# Altium schematic sheet Codec.SchDoc(Codec)
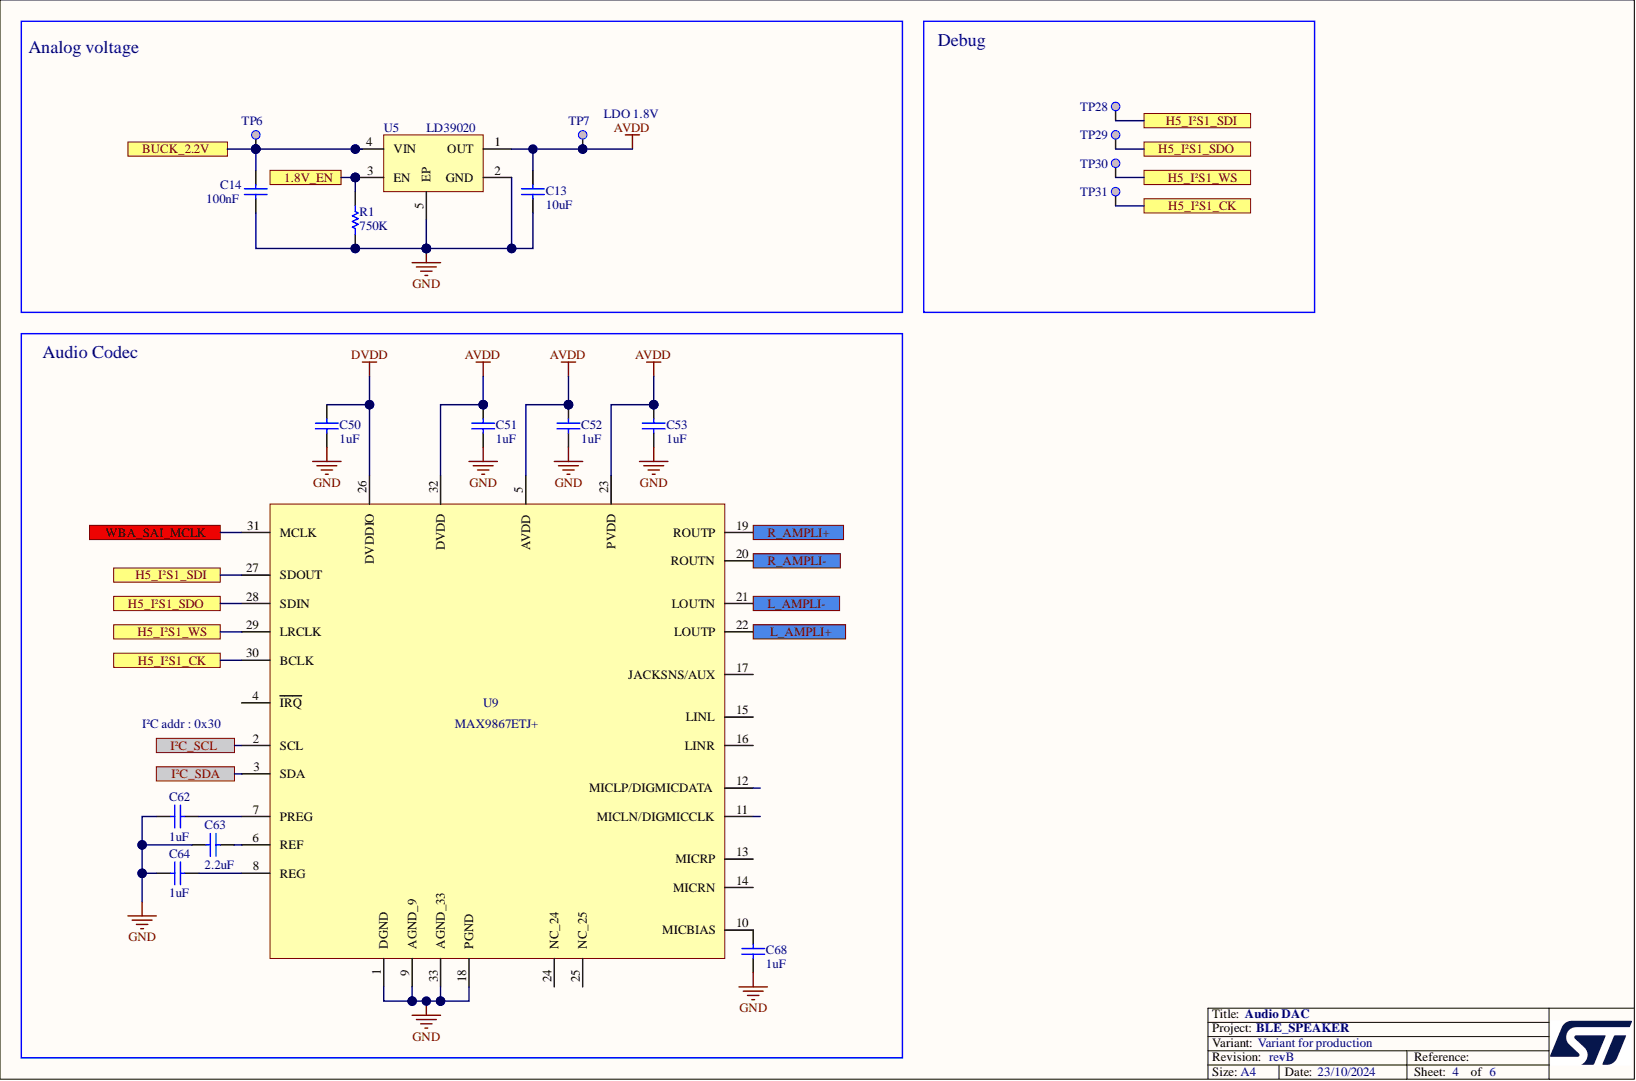
[diagram p0: Codec.SchDoc]

## Parts
C13  value 10uF  [C0402]  (hidden comment: Capacitor)
C14  value 100nF  [C0201_H0P3]  (hidden comment: Capacitor)
C50  value 1uF  [C0402]  (hidden comment: Capacitor)
C51  value 1uF  [C0402]  (hidden comment: Capacitor)
C52  value 1uF  [C0402]  (hidden comment: Capacitor)
C53  value 1uF  [C0402]  (hidden comment: Capacitor)
C62  value 1uF  [C0402]  (hidden comment: Capacitor)
C63  value 2.2uF  [C0402]  (hidden comment: Capacitor)
C64  value 1uF  [C0402]  (hidden comment: Capacitor)
C68  value 1uF  [C0402]  (hidden comment: Capacitor)
R1  value 750K  [R0402]  (hidden comment: Resistor)
TP6  Test Point  [TP_SMD_1MM]  (value not drawn)  (no BOM)
TP7  Test Point  [TP_SMD_1MM]  (value not drawn)  (no BOM)
TP28  Test Point  [TP_SMD_1MM]  (value not drawn)  (no BOM)
TP29  Test Point  [TP_SMD_1MM]  (value not drawn)  (no BOM)
TP30  Test Point  [TP_SMD_1MM]  (value not drawn)  (no BOM)
TP31  Test Point  [TP_SMD_1MM]  (value not drawn)  (no BOM)
U5  LD39020  [REG_XDFN4-EPAD_CASE-711AJ_1X1_H0P4_P065]
U9  MAX9867ETJ+  [ICC_TQFN32-EPAD_5X5_H0P75_P050]

## Ports
1.8V_EN, BUCK_2.2V, H5_I²S1_CK, H5_I²S1_SDI, H5_I²S1_SDO, H5_I²S1_WS, I²C_SCL, I²C_SDA, L_AMPLI+, L_AMPLI-, R_AMPLI+, R_AMPLI-, WBA_SAI_MCLK

## Nets
AVDD: C13.1 C51.1 C52.1 C53.1 TP7.1 U5.1(OUT) U9.5(AVDD) U9.23(PVDD) U9.32(DVDD)
DVDD: C50.1 U9.26(DVDDIO)
GND: C13.2 C14.2 C50.2 C51.2 C52.2 C53.2 C62.1 C63.1 C64.1 C68.2 R1.1 U5.2(GND) U5.5(EP) U9.1(DGND) U9.9(AGND_9) U9.18(PGND) U9.33(AGND_33)
1.8V_EN: R1.2 U5.3(EN) ; port 1.8V_EN
BUCK_2.2V: C14.1 TP6.1 U5.4(VIN) ; port BUCK_2.2V
H5_I²S1_CK: TP31.1 U9.30(BCLK) ; port H5_I²S1_CK
H5_I²S1_SDI: TP28.1 U9.27(SDOUT) ; port H5_I²S1_SDI
H5_I²S1_SDO: TP29.1 U9.28(SDIN) ; port H5_I²S1_SDO
H5_I²S1_WS: TP30.1 U9.29(LRCLK) ; port H5_I²S1_WS
I²C_SCL: U9.2(SCL) ; port I²C_SCL
I²C_SDA: U9.3(SDA) ; port I²C_SDA
WBA_SAI_MCLK: U9.31(MCLK) ; port WBA_SAI_MCLK
NetC62_2: C62.2 U9.7(PREG)
NetC63_2: C63.2 U9.6(REF)
NetC64_2: C64.2 U9.8(REG)
NetC68_1: C68.1 U9.10(MICBIAS)
NetU9_19: U9.19(ROUTP)
NetU9_20: U9.20(ROUTN)
NetU9_21: U9.21(LOUTN)
NetU9_22: U9.22(LOUTP)

Not connected: U9 4(IRQ) 11(MICLN/DIGMICCLK) 12(MICLP/DIGMICDATA) 13(MICRP) 14(MICRN) 15(LINL) 16(LINR) 17(JACKSNS/AUX) 24(NC_24) 25(NC_25)

## Library data of the parts
C13 = MCD-03140: Capacitor, MLCC, 10uF, 6.3V, X5R, +/-20%, 0402, T=0.5mm; Manufacturer: MURATA; Manufacturer_Part_Number: GRM155R60J106ME05D; Part_Preference: Recommended; Component_Country_Of_Origin: China, Japan; Database Table Name: MCD_PARTS$; ID: 5256; ShowFields: YES; Component Kind: Standard; ComponentLink1URL: http://tunmcdsvn.tun.st.com/Component_Documentation_Library/Trunk/Capacitor/MURATA_GRM_Series; Internal_Part_Number: MCD-03140; Library Name: MCD_PARTS_database.DbLib; Order_Code_Digikey: 490-GRM155R60J106ME05DTR-ND; Order_Code_Farnell: 3784428; Order_Code_RS: N/A; Package: 0402; Part_Type: Capacitor; Status: Released
C14 = MCD-00697: Capacitor, MLCC, 100nF,10V, X5R, +/-10%, 0201, T=0.3mm; Manufacturer: TDK; Manufacturer_Part_Number: C0603X5R1A104K030BC; Part_Preference: Recommended; Component_Country_Of_Origin: Unknown; Database Table Name: MCD_PARTS$; ID: 3046; ShowFields: YES; Component Kind: Standard; ComponentLink1URL: http://tunmcdsvn.tun.st.com/Component_Documentation_Library/Trunk/Capacitor/TDK_MCC_Series; Internal_Part_Number: MCD-00697; Library Name: MCD_PARTS_database.DbLib; Order_Code_Digikey: 445-7318-1-ND; Order_Code_Farnell: 2524957; Order_Code_RS: N/A; Package: 0201; Part_Type: Capacitor; Status: Released
C50-C53 C62 C64 C68 = MCD-01722: Capacitor, MLCC, 1uF, 10V, X5R, +/-10%, 0402, T=0.5mm; Manufacturer: YAGEO; Manufacturer_Part_Number: CC0402KRX5R6BB105; Part_Preference: Recommended; Component_Country_Of_Origin: China; Database Table Name: MCD_PARTS$; ID: 3822; ShowFields: YES; Component Kind: Standard; ComponentLink1URL: http://tunmcdsvn.tun.st.com/Component_Documentation_Library/Trunk/Capacitor/YAGEO_X5R_Series; Internal_Part_Number: MCD-01722; Library Name: MCD_PARTS_database.DbLib; Order_Code_Digikey: 311-1439-1-ND; Order_Code_Farnell: 1458896; Order_Code_RS: N/A; Package: 0402; Part_Type: Capacitor; Status: Released
C63 = MCD-01823: Capacitor, MLCC, 2.2uF, 6V3, X5R,+/-10%, 0402, T=0.5mm; Manufacturer: MURATA; Manufacturer_Part_Number: GRM155R60J225KE95D; Part_Preference: Recommended; Partial_Alternate_Internal_Part_Number: MCD-03566; Component_Country_Of_Origin: Japan°°; Database Table Name: MCD_PARTS$; ID: 3923; Order_Code_RS: 172-6478; ShowFields: YES; Component Kind: Standard; ComponentLink1URL: http://tunmcdsvn.tun.st.com/Component_Documentation_Library/Trunk/Capacitor/MURATA_GRM_Series; Internal_Part_Number: MCD-01823; Library Name: MCD_PARTS_database.DbLib; Order_Code_Digikey: 490-12532-1-ND; Order_Code_Farnell: 2695865; Package: 0402; Part_Type: Capacitor; Status: Released
R1 = MCD-00523: Resistor, 750K, 0402, +/-1%, 1/16W; Manufacturer: YAGEO; Manufacturer_Part_Number: RC0402FR-07750KL; Part_Preference: Recommended; Component_Country_Of_Origin: China; Database Table Name: MCD_PARTS$; ID: 3219; ShowFields: YES; Component Kind: Standard; ComponentLink1URL: http://tunmcdsvn.tun.st.com/Component_Documentation_Library/Trunk/Resistor/YAGEO_RC_L_Series; Internal_Part_Number: MCD-00523; Library Name: MCD_PARTS_database.DbLib; Order_Code_Digikey: YAG3228CT-ND; Order_Code_Farnell: 1458827; Order_Code_RS: N/A; Package: 0402; Part_Type: Resistor; Status: Released
TP6 TP7 TP28-TP31 = MCD-00074: Test Point 1mm; Part_Preference: Recommended; Part_Type: Misc; Component_Country_Of_Origin: Unknown; Database Table Name: MCD_PARTS$; ID: 2518; ShowFields: YES; ComponentLink1URL: http://tunmcdsvn.tun.st.com/Component_Documentation_Library/Trunk/Misc/; Internal_Part_Number: MCD-00074; Library Name: MCD_PARTS_database.DbLib; Manufacturer: N/A; Manufacturer_HQ_COO: Unknown; Manufacturer_Part_Number: N/A; Order_Code_Digikey: N/A; Order_Code_Farnell: N/A; Order_Code_RS: N/A; Status: Released; Value: Test Point 1mm
U5 = MCD-02224: LDO, 200mA, Very low quiescent current linear regulator IC; Manufacturer: STMICROELECTRONICS; Manufacturer_HQ_COO: EU; Manufacturer_Part_Number: LD39020ADTPU18R; Part_Preference: Recommended; Component_Country_Of_Origin: China; Database Table Name: MCD_PARTS$; ID: 4324; ShowFields: YES; Value: LD39020ADTPU18R; Component Kind: Standard; ComponentLink1URL: http://tunmcdsvn.tun.st.com/Component_Documentation_Library/Trunk/IC/STMICROELECTRONICS_LD39020ADTPU18R; Internal_Part_Number: MCD-02224; Library Name: MCD_PARTS_database.DbLib; Order_Code_Digikey: 497-15493-1-ND; Order_Code_Farnell: N/A; Order_Code_RS: N/A; Package: DFN-4; Part_Type: IC; Status: Released
U9 = MCD-01621: Stereo Codec, Ultra-Low power stereo audio codec; Manufacturer: MAXIM INTEGRATED; Part_Preference: Recommended; Part_Type: IC; Component_Country_Of_Origin: China; Database Table Name: MCD_PARTS$; ID: 3721; Order_Code_RS: 190-0463; ShowFields: YES; Component Kind: Standard; ComponentLink1URL: http://tunmcdsvn.tun.st.com/Component_Documentation_Library/Trunk/IC/MAXIM_INTEGRATED_MAX9867ETJ+; Internal_Part_Number: MCD-01621; Library Name: MCD_PARTS_database.DbLib; Manufacturer_Part_Number: MAX9867ETJ+; Order_Code_Digikey: MAX9867ETJ+-ND; Order_Code_Farnell: 2517267; Package: TQFN-EP-32; Status: Released; Value: MAX9867ETJ+
